# Altium schematic sheet Pagrindinis.SchDoc(Pagrindinis)
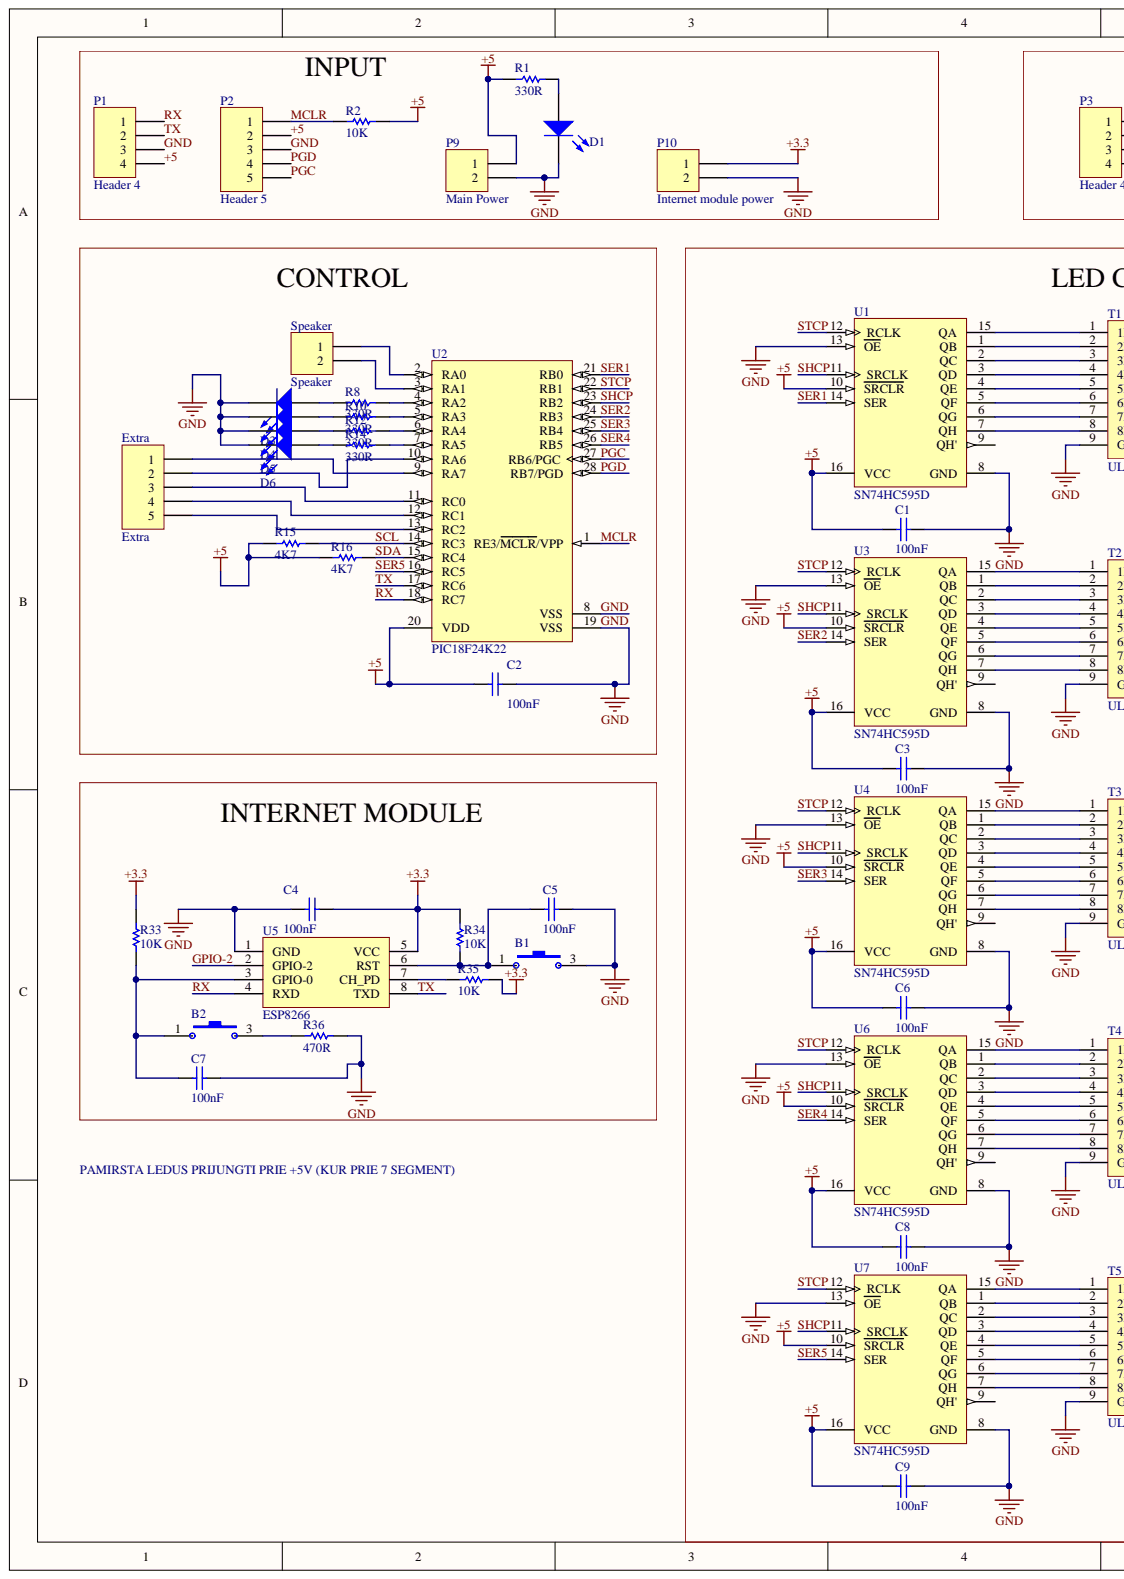
[diagram p0: Pagrindinis.SchDoc, left]
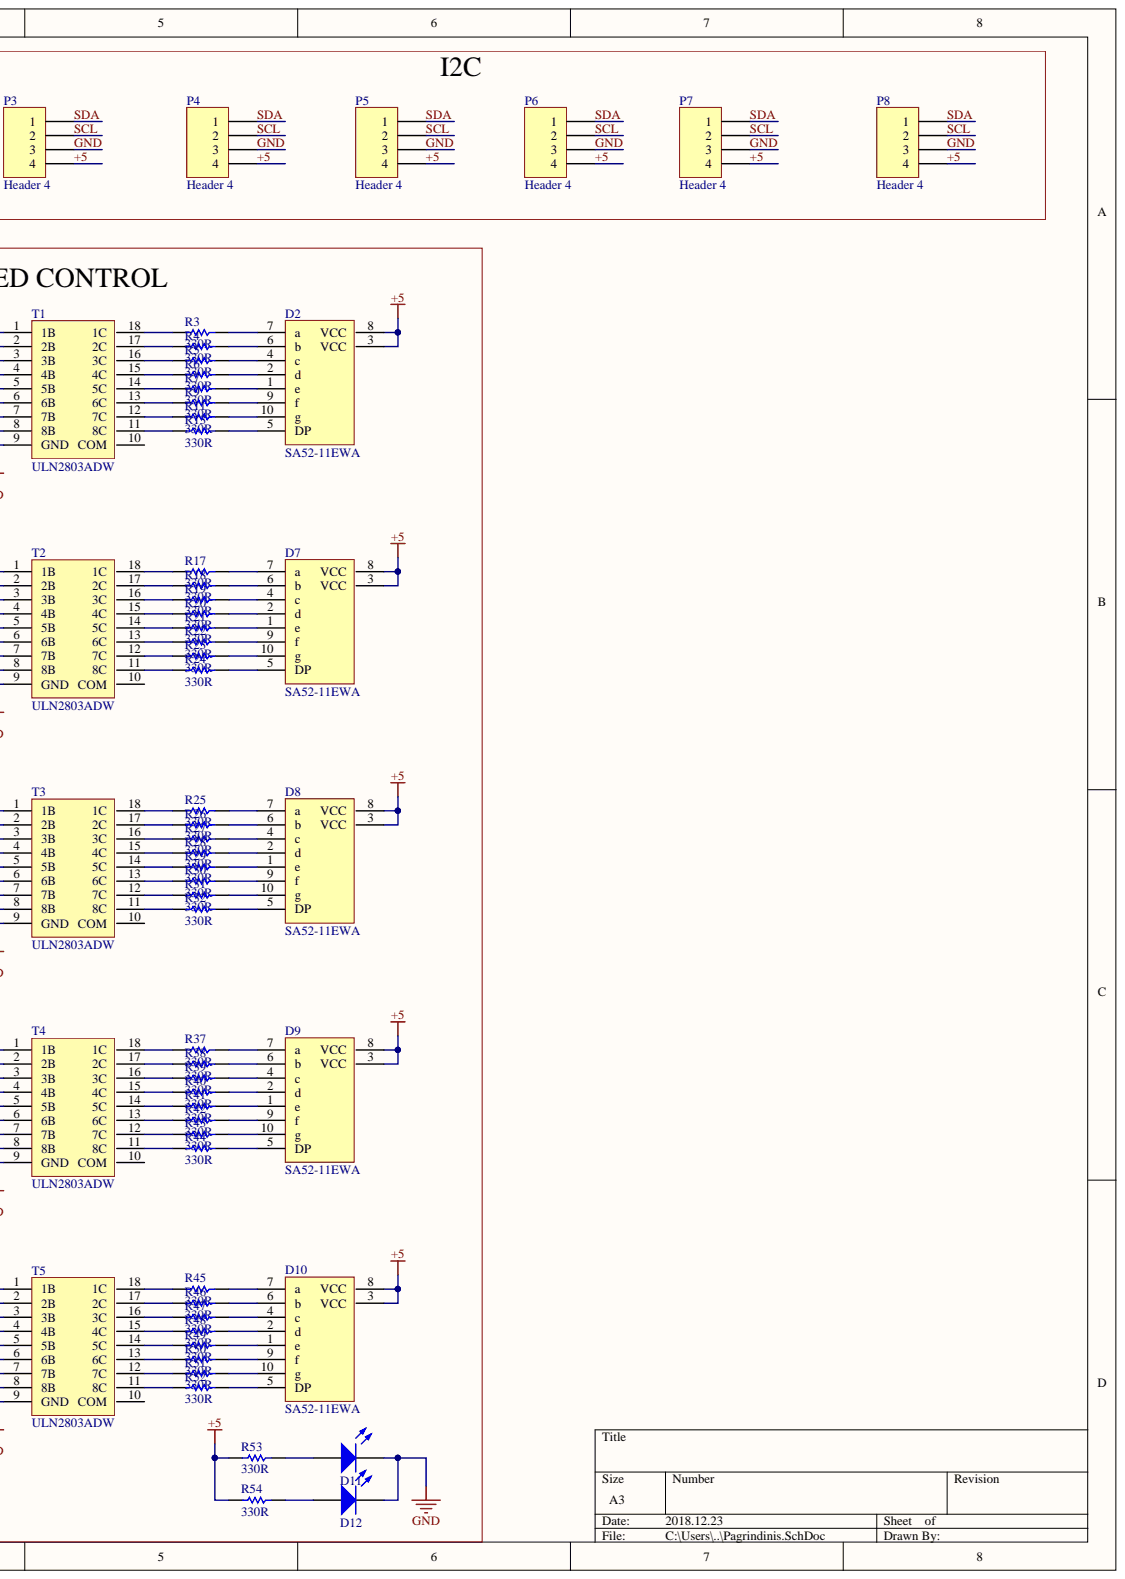
[diagram p1: Pagrindinis.SchDoc, right]

## Parts
B1  SW-PB SMD 4 pin  [SW-PB SMD 4 pin]  (value not drawn)
B2  SW-PB SMD 4 pin  [SW-PB SMD 4 pin]  (value not drawn)
C1  Capacitance: 100nF  [Capacitor 0805 non_pol]  (hidden comment: Capacitor SMD 0805)
C2  Capacitance: 100nF  [Capacitor 0805 non_pol]  (hidden comment: Capacitor SMD 0805)
C3  Capacitance: 100nF  [Capacitor 0805 non_pol]  (hidden comment: Capacitor SMD 0805)
C4  Capacitance: 100nF  [Capacitor 0805 non_pol]  (hidden comment: Capacitor SMD 0805)
C5  Capacitance: 100nF  [Capacitor 0805 non_pol]  (hidden comment: Capacitor SMD 0805)
C6  Capacitance: 100nF  [Capacitor 0805 non_pol]  (hidden comment: Capacitor SMD 0805)
C7  Capacitance: 100nF  [Capacitor 0805 non_pol]  (hidden comment: Capacitor SMD 0805)
C8  Capacitance: 100nF  [Capacitor 0805 non_pol]  (hidden comment: Capacitor SMD 0805)
C9  Capacitance: 100nF  [Capacitor 0805 non_pol]  (hidden comment: Capacitor SMD 0805)
D1  SMD 0805 RED  [SMD 0805 RED]  (value not drawn)
D2  SA52-11EWA  [SA52-11EWA]
D3  SMD 0805 RED  [SMD 0805 RED]  (value not drawn)
D4  SMD 0805 RED  [SMD 0805 RED]  (value not drawn)
D5  SMD 0805 RED  [SMD 0805 RED]  (value not drawn)
D6  SMD 0805 RED  [SMD 0805 RED]  (value not drawn)
D7  SA52-11EWA  [SA52-11EWA]
D8  SA52-11EWA  [SA52-11EWA]
D9  SA52-11EWA  [SA52-11EWA]
D10  SA52-11EWA  [SA52-11EWA]
D11  SMD 0805 RED  [SMD 0805 RED]  (value not drawn)
D12  SMD 0805 RED  [SMD 0805 RED]  (value not drawn)
Extra  Extra  [HDR1X5]
P1  Header 4  [HDR1X4]
P2  Header 5  [HDR1X5]
P3  Header 4  [HDR1X4]
P4  Header 4  [HDR1X4]
P5  Header 4  [HDR1X4]
P6  Header 4  [HDR1X4]
P7  Header 4  [HDR1X4]
P8  Header 4  [HDR1X4]
P9  Main Power  [HDR1X2]
P10  Internet module power  [HDR1X2]
R1  R 330R SMD 0805  [Resistor SMD 0805]  (value not drawn)
R2  Resistance: 10K  [Resistor SMD 0805]  (hidden comment: R 10K SMD 0805)
R3  R 330R SMD 0805  [Resistor SMD 0805]  (value not drawn)
R4  R 330R SMD 0805  [Resistor SMD 0805]  (value not drawn)
R5  R 330R SMD 0805  [Resistor SMD 0805]  (value not drawn)
R6  R 330R SMD 0805  [Resistor SMD 0805]  (value not drawn)
R7  R 330R SMD 0805  [Resistor SMD 0805]  (value not drawn)
R8  R 330R SMD 0805  [Resistor SMD 0805]  (value not drawn)
R9  R 330R SMD 0805  [Resistor SMD 0805]  (value not drawn)
R10  R 330R SMD 0805  [Resistor SMD 0805]  (value not drawn)
R11  R 330R SMD 0805  [Resistor SMD 0805]  (value not drawn)
R12  R 330R SMD 0805  [Resistor SMD 0805]  (value not drawn)
R13  R 330R SMD 0805  [Resistor SMD 0805]  (value not drawn)
R14  R 330R SMD 0805  [Resistor SMD 0805]  (value not drawn)
R15  Resistance: 4K7  [Resistor SMD 0805]  (hidden comment: R 4K7 SMD 0805)
R16  Resistance: 4K7  [Resistor SMD 0805]  (hidden comment: R 4K7 SMD 0805)
R17  R 330R SMD 0805  [Resistor SMD 0805]  (value not drawn)
R18  R 330R SMD 0805  [Resistor SMD 0805]  (value not drawn)
R19  R 330R SMD 0805  [Resistor SMD 0805]  (value not drawn)
R20  R 330R SMD 0805  [Resistor SMD 0805]  (value not drawn)
R21  R 330R SMD 0805  [Resistor SMD 0805]  (value not drawn)
R22  R 330R SMD 0805  [Resistor SMD 0805]  (value not drawn)
R23  R 330R SMD 0805  [Resistor SMD 0805]  (value not drawn)
R24  R 330R SMD 0805  [Resistor SMD 0805]  (value not drawn)
R25  R 330R SMD 0805  [Resistor SMD 0805]  (value not drawn)
R26  R 330R SMD 0805  [Resistor SMD 0805]  (value not drawn)
R27  R 330R SMD 0805  [Resistor SMD 0805]  (value not drawn)
R28  R 330R SMD 0805  [Resistor SMD 0805]  (value not drawn)
R29  R 330R SMD 0805  [Resistor SMD 0805]  (value not drawn)
R30  R 330R SMD 0805  [Resistor SMD 0805]  (value not drawn)
R31  R 330R SMD 0805  [Resistor SMD 0805]  (value not drawn)
R32  R 330R SMD 0805  [Resistor SMD 0805]  (value not drawn)
R33  Resistance: 10K  [Resistor SMD 0805]  (hidden comment: R 10K SMD 0805)
R34  Resistance: 10K  [Resistor SMD 0805]  (hidden comment: R 10K SMD 0805)
R35  Resistance: 10K  [Resistor SMD 0805]  (hidden comment: R 10K SMD 0805)
R36  R 470R SMD 0805  [Resistor SMD 0805]  (value not drawn)
R37  R 330R SMD 0805  [Resistor SMD 0805]  (value not drawn)
R38  R 330R SMD 0805  [Resistor SMD 0805]  (value not drawn)
R39  R 330R SMD 0805  [Resistor SMD 0805]  (value not drawn)
R40  R 330R SMD 0805  [Resistor SMD 0805]  (value not drawn)
R41  R 330R SMD 0805  [Resistor SMD 0805]  (value not drawn)
R42  R 330R SMD 0805  [Resistor SMD 0805]  (value not drawn)
R43  R 330R SMD 0805  [Resistor SMD 0805]  (value not drawn)
R44  R 330R SMD 0805  [Resistor SMD 0805]  (value not drawn)
R45  R 330R SMD 0805  [Resistor SMD 0805]  (value not drawn)
R46  R 330R SMD 0805  [Resistor SMD 0805]  (value not drawn)
R47  R 330R SMD 0805  [Resistor SMD 0805]  (value not drawn)
R48  R 330R SMD 0805  [Resistor SMD 0805]  (value not drawn)
R49  R 330R SMD 0805  [Resistor SMD 0805]  (value not drawn)
R50  R 330R SMD 0805  [Resistor SMD 0805]  (value not drawn)
R51  R 330R SMD 0805  [Resistor SMD 0805]  (value not drawn)
R52  R 330R SMD 0805  [Resistor SMD 0805]  (value not drawn)
R53  R 330R SMD 0805  [Resistor SMD 0805]  (value not drawn)
R54  R 330R SMD 0805  [Resistor SMD 0805]  (value not drawn)
Speaker  Speaker  [HDR1X2]
T1  ULN2803ADW  [SOP18]
T2  ULN2803ADW  [SOP18]
T3  ULN2803ADW  [SOP18]
T4  ULN2803ADW  [SOP18]
T5  ULN2803ADW  [SOP18]
U1  SN74HC595D  [D0016A_N]
U2  PIC18F24K22  [PIC18F24K22]
U3  SN74HC595D  [D0016A_N]
U4  SN74HC595D  [D0016A_N]
U5  ESP8266  [ESP8266]
U6  SN74HC595D  [D0016A_N]
U7  SN74HC595D  [D0016A_N]

## Nets
+3.3: C4.2 P10.1 R33.2 R34.2 R35.2 U5.5(VCC)
+5: C1.1 C2.2 C3.1 C6.1 C8.1 C9.1 D2.3(VCC) D2.8(VCC) D7.3(VCC) D7.8(VCC) D8.3(VCC) D8.8(VCC) D9.3(VCC) D9.8(VCC) D10.3(VCC) D10.8(VCC) P1.4 P2.2 P3.4 P4.4 P5.4 P6.4 P7.4 P8.4 P9.1 R1.2 R2.2 R15.1 R16.1 R53.1 R54.1 U1.10(SRCLR) U1.16(VCC) U2.20(VDD) U3.10(SRCLR) U3.16(VCC) U4.10(SRCLR) U4.16(VCC) U6.10(SRCLR) U6.16(VCC) U7.10(SRCLR) U7.16(VCC)
GND: B1.3 C1.2 C2.1 C3.2 C4.1 C5.1 C6.2 C7.2 C8.2 C9.2 D1.2 D3.2 D4.2 D5.2 D6.2 D11.2 D12.2 P1.3 P2.3 P3.3 P4.3 P5.3 P6.3 P7.3 P8.3 P9.2 P10.2 R36.2 T1.9(GND) T2.9(GND) T3.9(GND) T4.9(GND) T5.9(GND) U1.8(GND) U1.13(OE) U2.8(VSS) U2.19(VSS) U3.8(GND) U3.13(OE) U4.8(GND) U4.13(OE) U5.1(GND) U6.8(GND) U6.13(OE) U7.8(GND) U7.13(OE)
GPIO-2: U5.2(GPIO-2)
MCLR: P2.1 R2.1 U2.1(RE3/MCLR/VPP)
PGC: P2.5 U2.27(RB6/PGC)
PGD: P2.4 U2.28(RB7/PGD)
RX: P1.1 U2.18(RC7) U5.4(RXD)
SCL: P3.2 P4.2 P5.2 P6.2 P7.2 P8.2 R15.2 U2.14(RC3)
SDA: P3.1 P4.1 P5.1 P6.1 P7.1 P8.1 R16.2 U2.15(RC4)
SER1: U1.14(SER) U2.21(RB0)
SER2: U2.24(RB3) U3.14(SER)
SER3: U2.25(RB4) U4.14(SER)
SER4: U2.26(RB5) U6.14(SER)
SER5: U2.16(RC5) U7.14(SER)
SHCP: U1.11(SRCLK) U2.23(RB2) U3.11(SRCLK) U4.11(SRCLK) U6.11(SRCLK) U7.11(SRCLK)
STCP: U1.12(RCLK) U2.22(RB1) U3.12(RCLK) U4.12(RCLK) U6.12(RCLK) U7.12(RCLK)
TX: P1.2 U2.17(RC6) U5.8(TXD)
NetB1_1: B1.1 C5.2 R34.1 U5.6(RST)
NetB2_1: B2.1 C7.1 R33.1 U5.3(GPIO-0)
NetB2_3: B2.3 R36.1
NetD1_1: D1.1 R1.1
NetD2_1: D2.1(e) R7.2
NetD2_2: D2.2(d) R6.2
NetD2_4: D2.4(c) R5.2
NetD2_5: D2.5(DP) R13.2
NetD2_6: D2.6(b) R4.2
NetD2_7: D2.7(a) R3.2
NetD2_9: D2.9(f) R9.2
NetD2_10: D2.10(g) R11.2
NetD3_1: D3.1 R8.1
NetD4_1: D4.1 R10.1
NetD5_1: D5.1 R12.1
NetD6_1: D6.1 R14.1
NetD7_1: D7.1(e) R21.2
NetD7_2: D7.2(d) R20.2
NetD7_4: D7.4(c) R19.2
NetD7_5: D7.5(DP) R24.2
NetD7_6: D7.6(b) R18.2
NetD7_7: D7.7(a) R17.2
NetD7_9: D7.9(f) R22.2
NetD7_10: D7.10(g) R23.2
NetD8_1: D8.1(e) R29.2
NetD8_2: D8.2(d) R28.2
NetD8_4: D8.4(c) R27.2
NetD8_5: D8.5(DP) R32.2
NetD8_6: D8.6(b) R26.2
NetD8_7: D8.7(a) R25.2
NetD8_9: D8.9(f) R30.2
NetD8_10: D8.10(g) R31.2
NetD9_1: D9.1(e) R41.2
NetD9_2: D9.2(d) R40.2
NetD9_4: D9.4(c) R39.2
NetD9_5: D9.5(DP) R44.2
NetD9_6: D9.6(b) R38.2
NetD9_7: D9.7(a) R37.2
NetD9_9: D9.9(f) R42.2
NetD9_10: D9.10(g) R43.2
NetD10_1: D10.1(e) R49.2
NetD10_2: D10.2(d) R48.2
NetD10_4: D10.4(c) R47.2
NetD10_5: D10.5(DP) R52.2
NetD10_6: D10.6(b) R46.2
NetD10_7: D10.7(a) R45.2
NetD10_9: D10.9(f) R50.2
NetD10_10: D10.10(g) R51.2
NetD11_1: D11.1 R53.2
NetD12_1: D12.1 R54.2
NetExtra_1: Extra.1 U2.9(RA7)
NetExtra_2: Extra.2 U2.10(RA6)
NetExtra_3: Extra.3 U2.11(RC0)
NetExtra_4: Extra.4 U2.12(RC1)
NetExtra_5: Extra.5 U2.13(RC2)
NetR3_1: R3.1 T1.18(1C)
NetR4_1: R4.1 T1.17(2C)
NetR5_1: R5.1 T1.16(3C)
NetR6_1: R6.1 T1.15(4C)
NetR7_1: R7.1 T1.14(5C)
NetR8_2: R8.2 U2.4(RA2)
NetR9_1: R9.1 T1.13(6C)
NetR10_2: R10.2 U2.5(RA3)
NetR11_1: R11.1 T1.12(7C)
NetR12_2: R12.2 U2.6(RA4)
NetR13_1: R13.1 T1.11(8C)
NetR14_2: R14.2 U2.7(RA5)
NetR17_1: R17.1 T2.18(1C)
NetR18_1: R18.1 T2.17(2C)
NetR19_1: R19.1 T2.16(3C)
NetR20_1: R20.1 T2.15(4C)
NetR21_1: R21.1 T2.14(5C)
NetR22_1: R22.1 T2.13(6C)
NetR23_1: R23.1 T2.12(7C)
NetR24_1: R24.1 T2.11(8C)
NetR25_1: R25.1 T3.18(1C)
NetR26_1: R26.1 T3.17(2C)
NetR27_1: R27.1 T3.16(3C)
NetR28_1: R28.1 T3.15(4C)
NetR29_1: R29.1 T3.14(5C)
NetR30_1: R30.1 T3.13(6C)
NetR31_1: R31.1 T3.12(7C)
NetR32_1: R32.1 T3.11(8C)
NetR35_1: R35.1 U5.7(CH_PD)
NetR37_1: R37.1 T4.18(1C)
NetR38_1: R38.1 T4.17(2C)
NetR39_1: R39.1 T4.16(3C)
NetR40_1: R40.1 T4.15(4C)
NetR41_1: R41.1 T4.14(5C)
NetR42_1: R42.1 T4.13(6C)
NetR43_1: R43.1 T4.12(7C)
NetR44_1: R44.1 T4.11(8C)
NetR45_1: R45.1 T5.18(1C)
NetR46_1: R46.1 T5.17(2C)
NetR47_1: R47.1 T5.16(3C)
NetR48_1: R48.1 T5.15(4C)
NetR49_1: R49.1 T5.14(5C)
NetR50_1: R50.1 T5.13(6C)
NetR51_1: R51.1 T5.12(7C)
NetR52_1: R52.1 T5.11(8C)
NetSpeaker_1: Speaker.1 U2.2(RA0)
NetSpeaker_2: Speaker.2 U2.3(RA1)
NetT1_1: T1.1(1B) U1.15(QA)
NetT1_2: T1.2(2B) U1.1(QB)
NetT1_3: T1.3(3B) U1.2(QC)
NetT1_4: T1.4(4B) U1.3(QD)
NetT1_5: T1.5(5B) U1.4(QE)
NetT1_6: T1.6(6B) U1.5(QF)
NetT1_7: T1.7(7B) U1.6(QG)
NetT1_8: T1.8(8B) U1.7(QH)
NetT2_1: T2.1(1B) U3.15(QA)
NetT2_2: T2.2(2B) U3.1(QB)
NetT2_3: T2.3(3B) U3.2(QC)
NetT2_4: T2.4(4B) U3.3(QD)
NetT2_5: T2.5(5B) U3.4(QE)
NetT2_6: T2.6(6B) U3.5(QF)
NetT2_7: T2.7(7B) U3.6(QG)
NetT2_8: T2.8(8B) U3.7(QH)
NetT3_1: T3.1(1B) U4.15(QA)
NetT3_2: T3.2(2B) U4.1(QB)
NetT3_3: T3.3(3B) U4.2(QC)
NetT3_4: T3.4(4B) U4.3(QD)
NetT3_5: T3.5(5B) U4.4(QE)
NetT3_6: T3.6(6B) U4.5(QF)
NetT3_7: T3.7(7B) U4.6(QG)
NetT3_8: T3.8(8B) U4.7(QH)
NetT4_1: T4.1(1B) U6.15(QA)
NetT4_2: T4.2(2B) U6.1(QB)
NetT4_3: T4.3(3B) U6.2(QC)
NetT4_4: T4.4(4B) U6.3(QD)
NetT4_5: T4.5(5B) U6.4(QE)
NetT4_6: T4.6(6B) U6.5(QF)
NetT4_7: T4.7(7B) U6.6(QG)
NetT4_8: T4.8(8B) U6.7(QH)
NetT5_1: T5.1(1B) U7.15(QA)
NetT5_2: T5.2(2B) U7.1(QB)
NetT5_3: T5.3(3B) U7.2(QC)
NetT5_4: T5.4(4B) U7.3(QD)
NetT5_5: T5.5(5B) U7.4(QE)
NetT5_6: T5.6(6B) U7.5(QF)
NetT5_7: T5.7(7B) U7.6(QG)
NetT5_8: T5.8(8B) U7.7(QH)

Not connected: T1 10(COM); T2 10(COM); T3 10(COM); T4 10(COM); T5 10(COM); U1 9(QH'); U3 9(QH'); U4 9(QH'); U6 9(QH'); U7 9(QH')

## Library data of the parts
D2 D7-D10 = SA52-11EWA: 13.2mm 7 segment com. anode; Component Kind: Standard; Library Name: LED.IntLib
Extra P2 = Header 5: Header, 5-Pin; Component Kind: Standard; LatestRevisionDate: 17-Jul-2002; LatestRevisionNote: Re-released for DXP Platform.; Library Name: Miscellaneous Connectors.IntLib; Signal Integrity: Connector; Publisher: Altium Limited
P1 P3-P8 = Header 4: Header, 4-Pin; Component Kind: Standard; LatestRevisionDate: 17-Jul-2002; LatestRevisionNote: Re-released for DXP Platform.; Library Name: Miscellaneous Connectors.IntLib; Signal Integrity: Connector; Publisher: Altium Limited
P9 P10 Speaker = Header 2: Header, 2-Pin; Component Kind: Standard; LatestRevisionDate: 17-Jul-2002; LatestRevisionNote: Re-released for DXP Platform.; Library Name: Miscellaneous Connectors.IntLib; Signal Integrity: Connector; Publisher: Altium Limited
U1 U3 U4 U6 U7 = SN74HC595D: 8-Bit Shift Registers With 3-State Output Registers, D0016A, TUBE; Manufacturer: Texas Instruments; 3-State Output: Yes; Bits: 8; F @ Nom Voltage(Max)(Mhz): 28; ICC @ Nom Voltage(Max)(mA): 0.08; Input Type: CMOS; Output Drive (IOL/IOH)(Max)(mA): 7.8/-7.8; Rating: Military; Technology Family: HC; tpd @ Nom Voltage(Max)(ns): 34; VCC(Max)(V): 6; VCC(Min)(V): 2; Code_JEDEC: MS-012 variation AC; Component Kind: Standard; ComponentLink1Description: Manufacturer URL; ComponentLink1URL: http://www.ti.com/; ComponentLink2Description: Datasheet; ComponentLink2URL: http://www.ti.com/lit/ds/symlink/sn74hc595.pdf; ComponentLink3Description: Package Specification; ComponentLink3URL: http://www.ti.com/lit/ml/mpds178g/mpds178g.pdf; DatasheetVersion: SCLS041H; Generic Part Number: SN74HC595; Library Name: TI_Logic_Flip-Flop,Latch,Register.IntLib; Operating Temperature Range(C): -40 to 85; Output Type: CMOS; PackageReference: D0016A; PartNumber: SN74HC595D; Voltage(Nom)(V): 6
U2 = PIC18F24K22: PIC18F24K22 I/SO; Component Kind: Standard; Library Name: MCU.IntLib
B1 B2 = SW-PB SMD 4 pin: Component Kind: Standard; Description: SW-PB SMD 4 pin; Library Name: Buttons.IntLib
C1-C9 = Capacitor SMD 0805: Component Kind: Standard; Library Name: Capacitors.IntLib
D1 D3-D6 D11 D12 = SMD 0805 RED: Component Kind: Standard; Library Name: LED.IntLib
R1 R3-R14 R17-R32 R37-R54 = R 330R SMD 0805: : 330R; Component Kind: Standard; Library Name: Resistors.IntLib
R2 R33-R35 = R 10K SMD 0805: Component Kind: Standard; Library Name: Resistors.IntLib
R15 R16 = R 4K7 SMD 0805: Component Kind: Standard; Library Name: Resistors.IntLib
R36 = R 470R SMD 0805: : 470R; Component Kind: Standard; Library Name: Resistors.IntLib
T1-T5 = ULN2803ADW: Component Kind: Standard; Library Name: Transistors.IntLib
U5 = ESP8266: Component Kind: Standard; Library Name: MCU.IntLib
